# Altium schematic sheet RFSensor.SchDoc
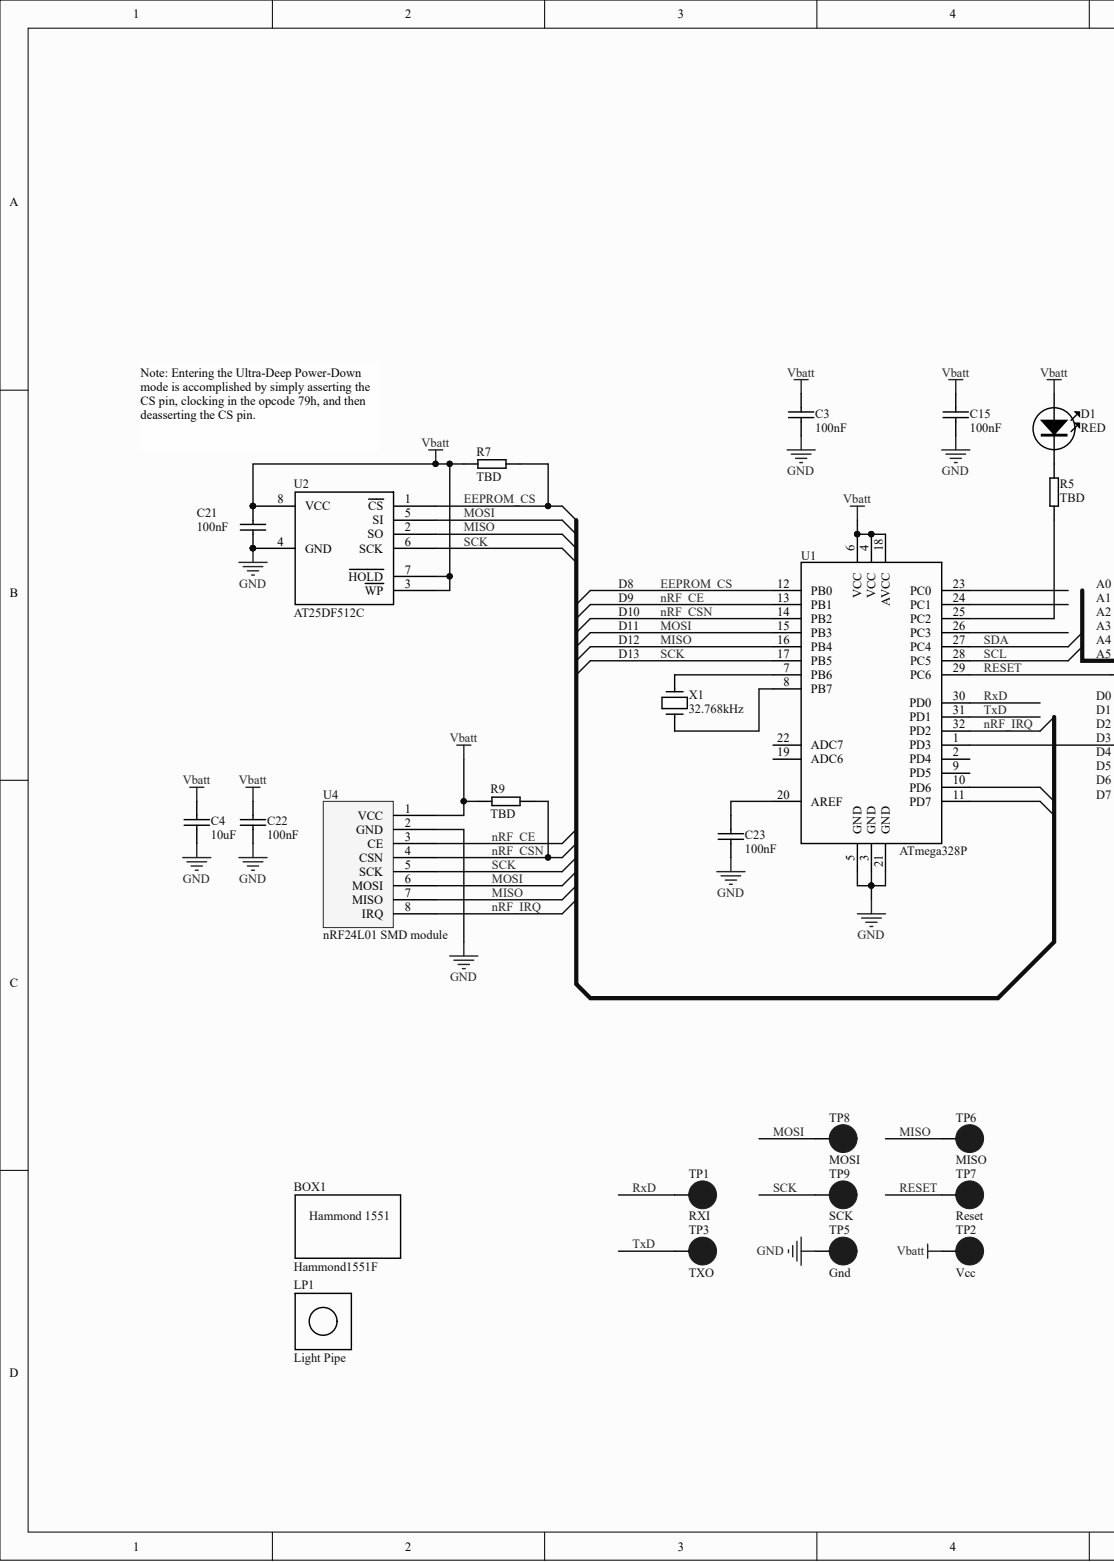
[diagram p0: RFSensor.SchDoc, left]
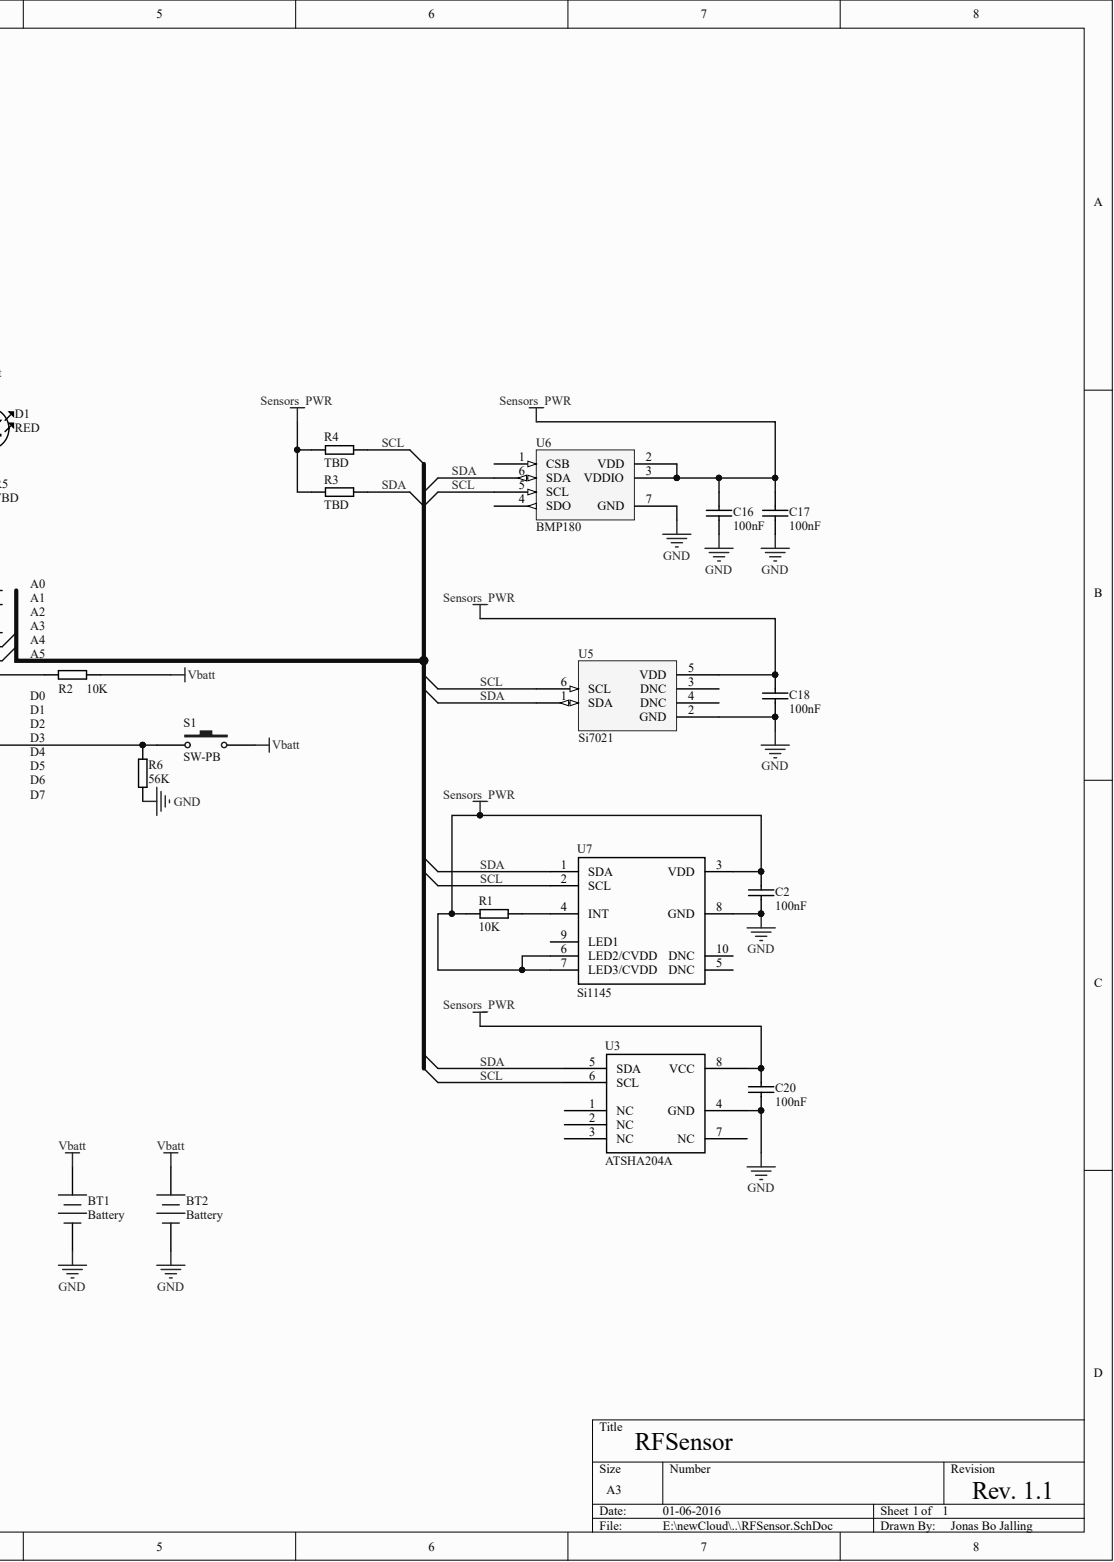
[diagram p1: RFSensor.SchDoc, right]

## Parts
BOX1  Hammond1551F  [HAMMOND1551F]
BT1  Battery  [BU2032SM-HD]
BT2  Battery  [BU2450SM]
C2  100nF  [0603C-100n]
C3  100nF  [0603C-GENERIC]
C4  10uF  [0805C-GENERIC]
C15  100nF  [0603C-GENERIC]
C16  100nF  [0603C-GENERIC]
C17  100nF  [0603C-GENERIC]
C18  100nF  [0603C-GENERIC]
C20  100nF  [0603C-GENERIC]
C21  100nF  [0603C-GENERIC]
C22  100nF  [0603C-GENERIC]
C23  100nF  [0603C-GENERIC]
D1  RED  [0805LED-RED]
LP1  Light Pipe  [VLB-xxx-x]
R1  10K  [0603R-10K]
R2  10K  [0603R-10K]
R3  TBD  [0603R-TBD]
R4  TBD  [0603R-TBD]
R5  TBD  [0603R-TBD]
R6  56K  [0603R-TBD]
R7  TBD  [0603R-TBD]
R9  TBD  [0603R-TBD]
S1  SW-PB  [FSM]
TP1  RXI  [TestPoint1.5]  (no BOM)
TP2  Vcc  [TestPoint1.5]  (no BOM)
TP3  TXO  [TestPoint1.5]  (no BOM)
TP5  Gnd  [TestPoint1.5]  (no BOM)
TP6  MISO  [TestPoint1.5]  (no BOM)
TP7  Reset  [TestPoint1.5]  (no BOM)
TP8  MOSI  [TestPoint1.5]  (no BOM)
TP9  SCK  [TestPoint1.5]  (no BOM)
U1  ATmega328P  [TQFP32]
U2  AT25DF512C  [8MA3]
U3  ATSHA204A  [8MA3]
U4  nRF24L01 SMD module  [NRF24L01SMD]
U5  Si7021  [QFN127P600-8N]
U6  BMP180  [QFN127P600-7N]
U7  Si1145  [DFN-10]
X1  32.768kHz  [AB26TRQ]

## Nets
GND: BT1.2 BT2.2 C2.2 C3.2 C4.2 C15.2 C16.2 C17.2 C18.2 C20.2 C21.2 C22.2 C23.2 R6.1 TP5.TP U1.3(GND) U1.5(GND) U1.21(GND) U2.4(GND) U3.4(GND) U4.2(GND) U5.2(GND) U6.7(GND) U7.8(GND)
SENSORS_PWR: C2.1 C16.1 C17.1 C18.1 C20.1 R1.1 R3.1 R4.1 U3.8(VCC) U5.5(VDD) U6.2(VDD) U6.3(VDDIO) U7.3(VDD) U7.6(LED2/CVDD) U7.7(LED3/CVDD)
VBATT: BT1.1 BT2.1 C3.1 C4.1 C15.1 C21.1 C22.1 D1.A R2.2 R7.1 R9.2 S1.2 TP2.TP U1.4(VCC) U1.6(VCC) U1.18(AVCC) U2.3(WP) U2.7(HOLD) U2.8(VCC) U4.1(VCC)
EEPROM_CS: R7.2 U1.12(PB0) U2.1(CS)
MISO: TP6.TP U1.16(PB4) U2.2(SO) U4.7(MISO)
MOSI: TP8.TP U1.15(PB3) U2.5(SI) U4.6(MOSI)
NRF_CE: U1.13(PB1) U4.3(CE)
NRF_CSN: R9.1 U1.14(PB2) U4.4(CSN)
NRF_IRQ: U1.32(PD2) U4.8(IRQ)
RESET: R2.1 TP7.TP U1.29(PC6)
RXD: TP1.TP U1.30(PD0)
SCK: TP9.TP U1.17(PB5) U2.6(SCK) U4.5(SCK)
SCL: R4.2 U1.28(PC5) U3.6(SCL) U5.6(SCL) U6.5(SCL) U7.2(SCL)
SDA: R3.2 U1.27(PC4) U3.5(SDA) U5.1(SDA) U6.6(SDA) U7.1(SDA)
TXD: TP3.TP U1.31(PD1)
NetC23_1: C23.1 U1.20(AREF)
NetD1_K: D1.K R5.1
NetR1_2: R1.2 U7.4(INT)
NetR5_2: R5.2 U1.25(PC2)
NetR6_2: R6.2 S1.1 U1.1(PD3)
NetU1_7: U1.7(PB6) X1.1
NetU1_8: U1.8(PB7) X1.2
N00005:
N00006:

Not connected: U1 2(PD4) 9(PD5) 10(PD6) 11(PD7) 19(ADC6) 22(ADC7) 23(PC0) 24(PC1) 26(PC3); U3 1(NC) 2(NC) 3(NC) 7(NC); U5 3(DNC) 4(DNC); U6 1(CSB) 4(SDO); U7 5(DNC) 9(LED1) 10(DNC)

## Library data of the parts
BT1 BT2 = Battery: Multicell Battery; Component Kind: Standard; LatestRevisionDate: 17-Jul-2002; LatestRevisionNote: Re-released for DXP Platform.; PackageDescription: Battery; 2 Leads; PackageReference: BAT-2; Published: 24-Mar-1999; Supplier 1: Digi-Key; Library Name: DefaultParts.IntLib; Publisher: Altium Limited
  BT1: Supplier Part Number 1: BU2032SM-HD-GCT-ND
  BT2: Supplier Part Number 1: BU2450SM-JJ-GTR-ND
C2 = 0603C-100NF-50V-X7R-KEMET: CAPACITOR 0603 CERAMIC; Component Kind: Standard; Supplier 1: Digi-Key; Supplier Part Number 1: 399-7845-1-ND; Library Name: JBJ_lib.IntLib
C3 C15-C18 C20-C23 = 0603C-100NF-25V-X7R-TDK: CAPACITOR 0603 CERAMIC; Manufacturer: TDK Corporation; Manufacturer Part Number: C1608X7R1E104K; Component Kind: Standard; Supplier 1: Digi-Key; Supplier Part Number 1: 445-1316-2-ND; Library Name: DefaultParts.IntLib
C4 = 0805C-10UF-6V3-X5R-PAN: CAPACITOR 0805 CERAMIC; Manufacturer: Panasonic - ECG; Manufacturer Part Number: ECJ-2FB0J106M; Capacitance: 10µF; Reel: C1; Temperature Coefficient: X5R; Tolerance: ±20%; Voltage - Rated: 6.3V; Category: Capacitors; Component Kind: Standard; Features: General Purpose; Lead Spacing: -; Library Name: vh_altium_library.intLib; Mounting Type: Surface Mount, MLCC; Operating Temperature: -55°C ~ 85°C; Package / Case: 0805 (2012 metric); Packaging: Tape & Reel (TR); RoHS: RoHS Compliant; Supplier: Digi-Key; Supplier 1: Digi-Key; Supplier Part Number: PCC2225TR-ND; Supplier Part Number 1: PCC2225TR-ND; Thickness: 1.25mm
D1 = CHIPLED-RED: CHIPLED RED; Component Kind: Standard; Supplier 1: Digi-Key; Library Name: JBJ_lib.IntLib
LP1 = LightPipe: Bivar LightPipe; Component Kind: Standard; Supplier 1: Digi-Key; Supplier Part Number 1: 492-1514-ND; Library Name: DefaultParts.IntLib
R1 R2 = 0603R-10K: GENERIC RESISTOR 0603; Component Kind: Standard; Supplier 1: Digi-Key; Library Name: DefaultParts.IntLib
R3-R7 R9 = 0603R-TBD: RESISTOR 0603 VALUE TO BE DETERMINED; Component Kind: Standard; Library Name: DefaultParts.IntLib
  R3-R5: Supplier 1: Digi-Key
S1 = SW-PB: Switch; Component Kind: Standard; LatestRevisionDate: 17-Jul-2002; LatestRevisionNote: Re-released for DXP Platform.; PackageDescription: Switch; 2 Leads; PackageReference: SPST-2; Published: 24-Mar-1999; Supplier 1: Digi-Key; Supplier Part Number 1: 450-1140-ND; Library Name: DefaultParts.IntLib; Publisher: Altium Limited
TP1-TP3 TP5-TP9 = TP1.5mm: Supplier 1: Digi-Key; Library Name: DefaultParts.IntLib
U4 = nRF24L01-smd-module: nRF24L01+ SMD module; Component Kind: Standard; Library Name: Schematic.SchLib; Supplier 1: Digi-Key
U5 = Si7021: I2C HUMIDITY AND TEMPERATURE SENSOR; Component Kind: Standard; Supplier 1: Digi-Key; Library Name: DefaultParts.IntLib
U6 = BMP180: Digital pressure sensor; Component Kind: Standard; Supplier 1: Digi-Key; Library Name: DefaultParts.IntLib
X1 = XTAL-12MC-7A-5MM-3.2MM: 32.768kHz CRYSTAL AB26TRQ; Component Kind: Standard; Supplier 1: Digi-Key; Supplier Part Number 1: 535-12051-1-ND; Library Name: JBJ_lib.IntLib
BOX1 = Hammond1551F: Component Kind: Standard; Supplier 1: Digi-Key; Supplier Part Number 1: HM907-ND; Library Name: JBJ_lib.IntLib
U1 = ATmega328P: Component Kind: Standard; Description: ATmega328P; Supplier 1: Digi-Key; Supplier Part Number 1: ATMEGA328PB-AN-ND; Library Name: JBJ_lib.IntLib
U2 = AT25DF512C: Component Kind: Standard; Library Name: IC Memory.SchLib; Supplier 1: Digi-Key; Supplier Part Number 1: 1265-1115-1-ND
U3 = ATSHA204A: Component Kind: Standard; Description: ATSHA204A; Supplier 1: Digi-Key; Supplier Part Number 1: ATSHA204A-MAHCZ-TCT-ND; Library Name: JBJ_lib.IntLib
U7 = Si1145: Component Kind: Standard; Library Name: JBJ_lib.IntLib
